# Altium schematic sheet Nixie_PWR_v2.SchDoc(Nixie_PWR_v2)
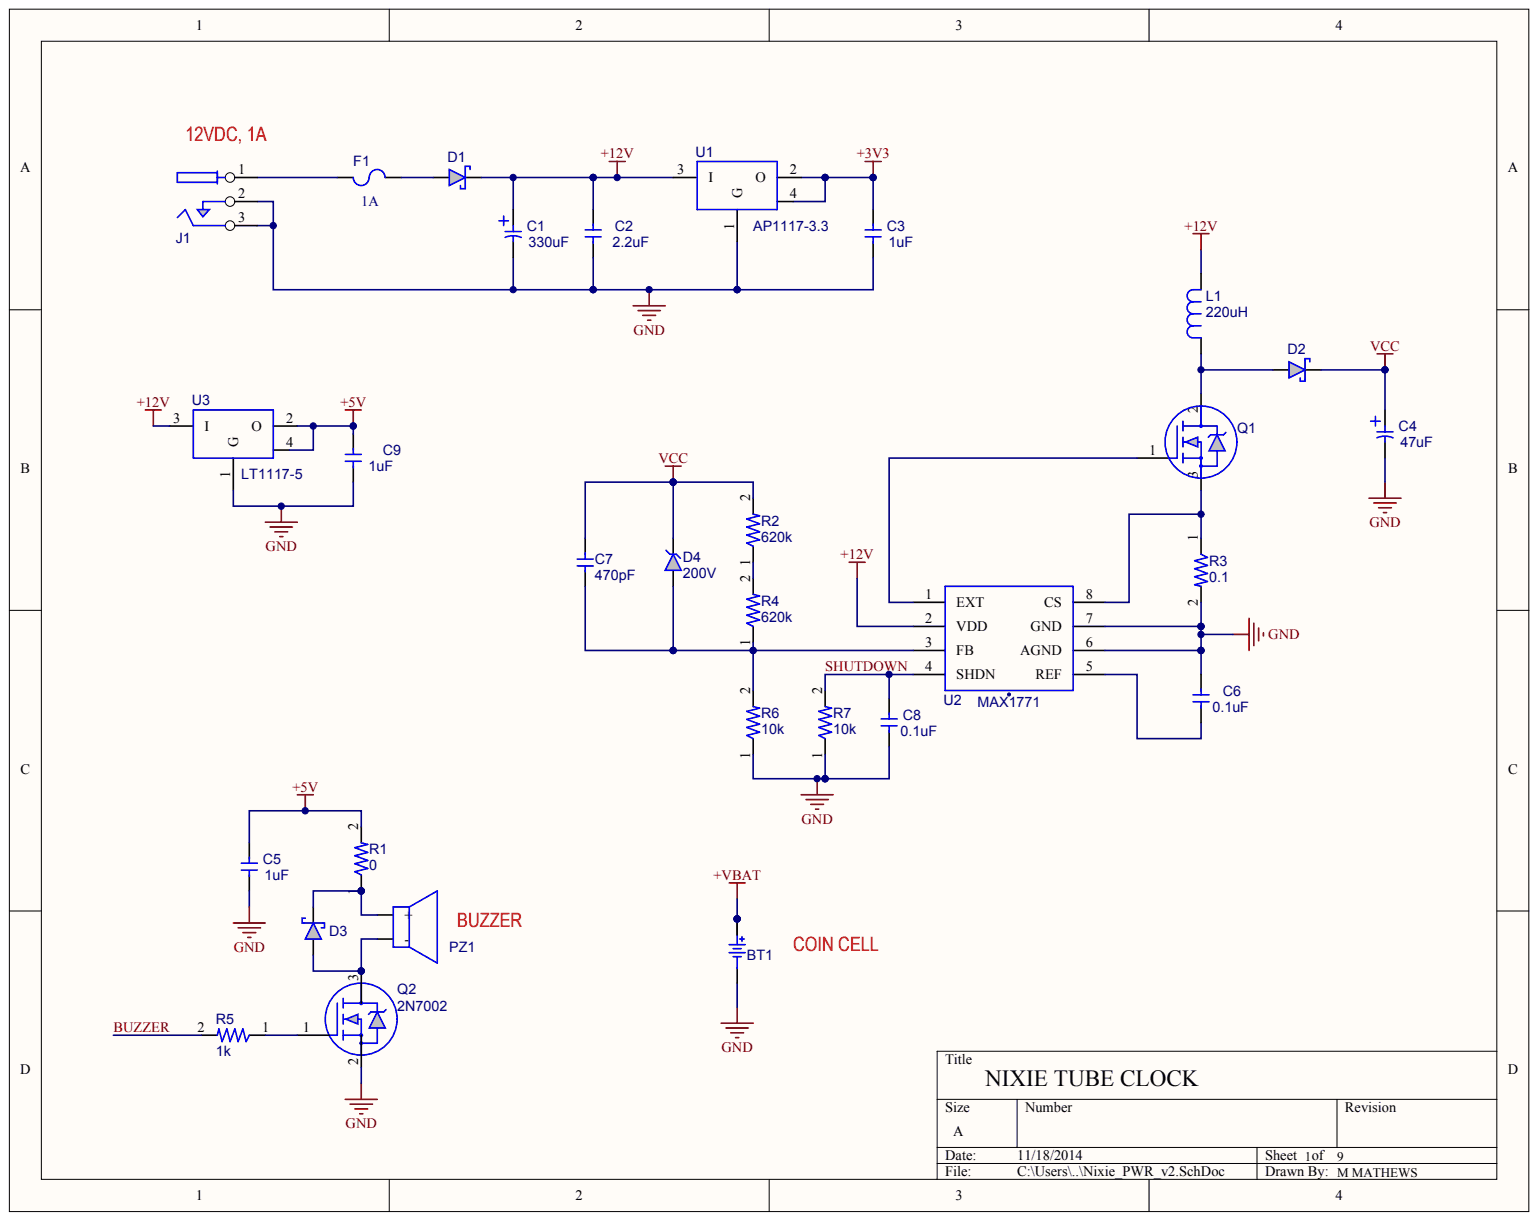
[diagram p0: Nixie_PWR_v2.SchDoc]

## Parts
BT1  BATT_Keystone 3002  (value not drawn)
C1  value 330uF  (hidden comment: CAP+)
C2  value 2.2uF  (hidden comment: CAP)
C3  value 1uF  (hidden comment: CAP)
C4  value 47uF  (hidden comment: CAP+)
C5  value 1uF  (hidden comment: CAP)
C6  value 0.1uF  (hidden comment: CAP)
C7  value 470pF  (hidden comment: CAP)
C8  value 0.1uF  (hidden comment: CAP)
C9  value 1uF  (hidden comment: CAP)
D1  B240A  (value not drawn)
D2  B240A  (value not drawn)
D3  1N5819HW  (value not drawn)
D4  200V
F1  value 1A  (hidden comment: 1206)
J1  PJ-002A  (value not drawn)
L1  value 220uH  (hidden comment: IND)
PZ1  BUZZER_SMT  [BUZZER_SMT_ext]  (value not drawn)
Q1  FDD5810_DPAK  (value not drawn)
Q2  2N7002
R1  value 0  (hidden comment: RES)
R2  value 620k  (hidden comment: RES)
R3  value 0.1  (hidden comment: RES)
R4  value 620k  (hidden comment: RES)
R5  value 1k  (hidden comment: RES)
R6  value 10k  (hidden comment: RES)
R7  value 10k  (hidden comment: RES)
U1  AP1117-3.3
U2  MAX1771
U3  LT1117-5

## Nets
+3V3: C3.1 U1.2(O) U1.4
+5V: C5.1 C9.1 R1.2 U3.2(O) U3.4
+12V: C1.1 C2.1 D1.1 L1.2 U1.3(I) U2.2(VDD) U3.3(I)
+VBAT: BT1.2 BT1.3
GND: BT1.1 C1.2 C2.2 C3.2 C4.2 C5.2 C6.1 C8.2 C9.2 J1.2 J1.3 Q2.2 R3.2 R6.1 R7.1 U1.1(G) U2.6(AGND) U2.7(GND) U3.1(G)
VCC: C4.1 C7.2 D2.1 D4.1 R2.2
BUZZER: R5.2
SHUTDOWN: C8.1 R7.2 U2.4(SHDN)
NetC6_2: C6.2 U2.5(REF)
NetC7_1: C7.1 D4.2 R4.1 R6.2 U2.3(FB)
NetD1_2: D1.2 F1.2
NetD2_2: D2.2 L1.1 Q1.2
NetD3_1: D3.1 PZ1.1 R1.1
NetD3_2: D3.2 PZ1.2 Q2.3
NetF1_1: F1.1 J1.1
NetQ1_1: Q1.1 U2.1(EXT)
NetQ1_3: Q1.3 R3.1 U2.8(CS)
NetQ2_1: Q2.1 R5.1
NetR2_1: R2.1 R4.2

## Library data of the parts
BT1 = BATT_Keystone 3002: Manufacturer Part Number: 3002; Tolerance: -; Voltage: -; Manufacturer: Keystone; Revision: A; Value: -; Cost: 0.523; Supplier 1: Digi-key; Supplier Part Number 1: 3002K-ND; Component Kind: Standard; IPC Pattern: SMD
C1 = (no symbol name): Manufacturer: Panasonic; Manufacturer Part Number: EEE-FK1E331P; Tolerance: 20%; Voltage: 25V; Revision: A; Cost: 0.804; Supplier 1: Digi-key; Supplier Part Number 1: PCE3803CT-ND; Component Kind: Standard; IPC Pattern: SMD
C2 = (no symbol name): Manufacturer: Samsung; Manufacturer Part Number: CL21B225KAFNFNE; Tolerance: 10%; Voltage: 25V; Revision: A; Component Kind: Standard; Cost: 0.11; Supplier 1: Digi-key; Supplier Part Number 1: 1276-2953-1-ND; IPC Pattern: 0805
C3 C5 C9 = (no symbol name): Manufacturer: Samsung; Manufacturer Part Number: CL21B105KBFNNNE; Tolerance: 10%; Voltage: 50V; Revision: A; Component Kind: Standard; Cost: 0.071; Supplier 1: Digi-key; Supplier Part Number 1: 1276-1029-1-ND; IPC Pattern: 0805
C4 = (no symbol name): Manufacturer: Panasonic; Manufacturer Part Number: EEU-ED2G470S; Tolerance: 20%; Voltage: 400V; Revision: A; Cost: 1.92; Supplier 1: Digi-key; Supplier Part Number 1: P13546-ND; IPC Pattern: TH
C6 C8 = (no symbol name): Manufacturer: Samsung; Manufacturer Part Number: CAP CER 0.1UF 50V 10% X7R 0603; Tolerance: 10%; Voltage: 50V; Revision: A; Component Kind: Standard; Cost: 0.0091; Supplier 1: Digi-key; Supplier Part Number 1: 1276-1936-1-ND; IPC Pattern: 0603
C7 = (no symbol name): Manufacturer: Samsung; Manufacturer Part Number: CL31B471KGFNNNE; Tolerance: 10%; Voltage: 500V; Revision: A; Component Kind: Standard; Cost: 0.166; Supplier 1: Digi-key; Supplier Part Number 1: 1276-3163-1-ND; IPC Pattern: 1206
D1 D2 = (no symbol name): Manufacturer: Rohm Semiconductor; Manufacturer Part Number: RF101L4STE25; Value: 1A; Voltage: 400V; Revision: A; Component Kind: Standard; Cost: 0.315; Supplier 1: Supplier 1; Supplier Part Number 1: RF101L4STE25CT-ND; Tolerance: -; IPC Pattern: SMA
D3 = (no symbol name): Manufacturer: Fairchild Semiconductor; Manufacturer Part Number: MBR0530; Voltage: 30V; Revision: A; Component Kind: Standard; Cost: 0.319; Supplier 1: Digi-key; Supplier Part Number 1: MBR0530CT-ND; Tolerance: -; Value: -; IPC Pattern: SOD-123
D4 = (no symbol name): Manufacturer: Vishay; Manufacturer Part Number: BZG03C200TR; Tolerance: 6%; Voltage: 200V; Revision: A; Value: -; Cost: 0.453; Supplier 1: Digi-key; Supplier Part Number 1: BZG03C200CT-ND; Component Kind: Standard; IPC Pattern: SMA
F1 = Fuse_1206: Manufacturer: Bel Fuse; Manufacturer Part Number: C1S 1; Voltage: 63V; Supplier 1: Farnell; Cost: 0.329; Component Kind: Standard; IPC Pattern: 1206; Supplier Part Number 1: 507-1186-1-ND; Tolerance: -
J1 = (no symbol name): Manufacturer: CUI, Inc; Tolerance: -; Voltage: -; Manufacturer Part Number: PJ-002A; Revision: A; Value: -; Cost: 0.93; Supplier 1: Digi-key; Supplier Part Number 1: CP-002A-ND; Component Kind: Standard; IPC Pattern: TH
L1 = (no symbol name): Manufacturer: TDK Corporation; Manufacturer Part Number: VLF10045T-221MR90; Tolerance: 20%; Voltage: -; Revision: A; Cost: 1.60; Supplier 1: Digi-key; Supplier Part Number 1: 445-3586-1-ND; Component Kind: Standard; IPC Pattern: SMD
PZ1 = BUZZER_SMT: Manufacturer: CUI, Inc; Manufacturer Part Number: CT-1205H-SMT-TR; Voltage: 5V; Tolerance: -; Revision: A; Value: -; Cost: 1.89; Supplier 1: Digi-key; Supplier Part Number 1: 102-1266-1-ND; Component Kind: Standard; IPC Pattern: SMD
Q1 Q2 = (no symbol name): Manufacturer: Fairchild Semiconductor; Manufacturer Part Number: BSS138; Voltage: 50V; Tolerance: -; Revision: A; Value: -; Cost: 0.1408; Supplier 1: Digi-key; Supplier Part Number 1: BSS138CT-ND; Component Kind: Standard; IPC Pattern: SOT23
R1 = (no symbol name): Manufacturer: Panasonic; Manufacturer Part Number: ERJ-6GEY0R00V; Tolerance: -; Voltage: -; Revision: A; Cost: 0.0149; Supplier 1: Digi-key; Supplier Part Number 1: P0.0ACT-ND; Component Kind: Standard; IPC Pattern: 0805
R2 R4 = (no symbol name): Manufacturer: Panasonic; Manufacturer Part Number: ERJ-6ENF6203V; Tolerance: 1%; Voltage: -; Revision: A; Cost: 0.0288; Supplier 1: Digi-eky; Supplier Part Number 1: P620KCCT-ND; Component Kind: Standard; IPC Pattern: 0805
R3 = (no symbol name): Manufacturer: Samsung; Manufacturer Part Number: CRM1206-FX-R100ELF; Tolerance: 1%; Voltage: -; Revision: A; Cost: 0.405; Supplier 1: Digi-key; Supplier Part Number 1: CRM1206-FX-R100ELFCT-ND; Component Kind: Standard; IPC Pattern: 1206
R5 = (no symbol name): Manufacturer: Panasonic; Manufacturer Part Number: ERJ-3GEYJ102V; Tolerance: 5%; Voltage: -; Revision: A; Cost: 0.0096; Supplier 1: Digi-key; Supplier Part Number 1: P1.0KGCT-ND; Component Kind: Standard; IPC Pattern: 0603
R6 R7 = (no symbol name): Manufacturer: Panasonic; Manufacturer Part Number: ERJ-3GEYJ103V; Tolerance: 5%; Voltage: -; Revision: A; Cost: 0.0096; Supplier 1: Digi-key; Supplier Part Number 1: P10KGCT-ND; Component Kind: Standard; IPC Pattern: 0603
U1 = (no symbol name): Manufacturer Part Number: AP1117IE33G-13; Manufacturer: Diodes, Inc; Voltage: 3.3V; Value: -; Component Kind: Standard; Cost: 0.322; IPC Pattern: SOT223-3; Supplier 1: Digi-key; Supplier Part Number 1: AP1117IE33G-13DICT-ND; Tolerance: -
U2 = (no symbol name): Manufacturer: Maxim; Manufacturer Part Number: MAX1771CSA+; Value: -; Cost: 5.244; Supplier 1: Digi-key; Supplier Part Number 1: MAX1771CSA+-ND; Component Kind: Standard; IPC Pattern: SOIC-8; Tolerance: -; Voltage: -
U3 = (no symbol name): Manufacturer Part Number: AP1117E50G-13; Manufacturer: Diodes, Inc; Voltage: 5V; Value: -; Component Kind: Standard; Cost: 0.409; IPC Pattern: SOT223-3; Supplier 1: Digi-key; Supplier Part Number 1: AP1117E50GDICT-ND; Tolerance: -
